# Altium schematic sheet Sheet1.SchDoc(Sheet1)
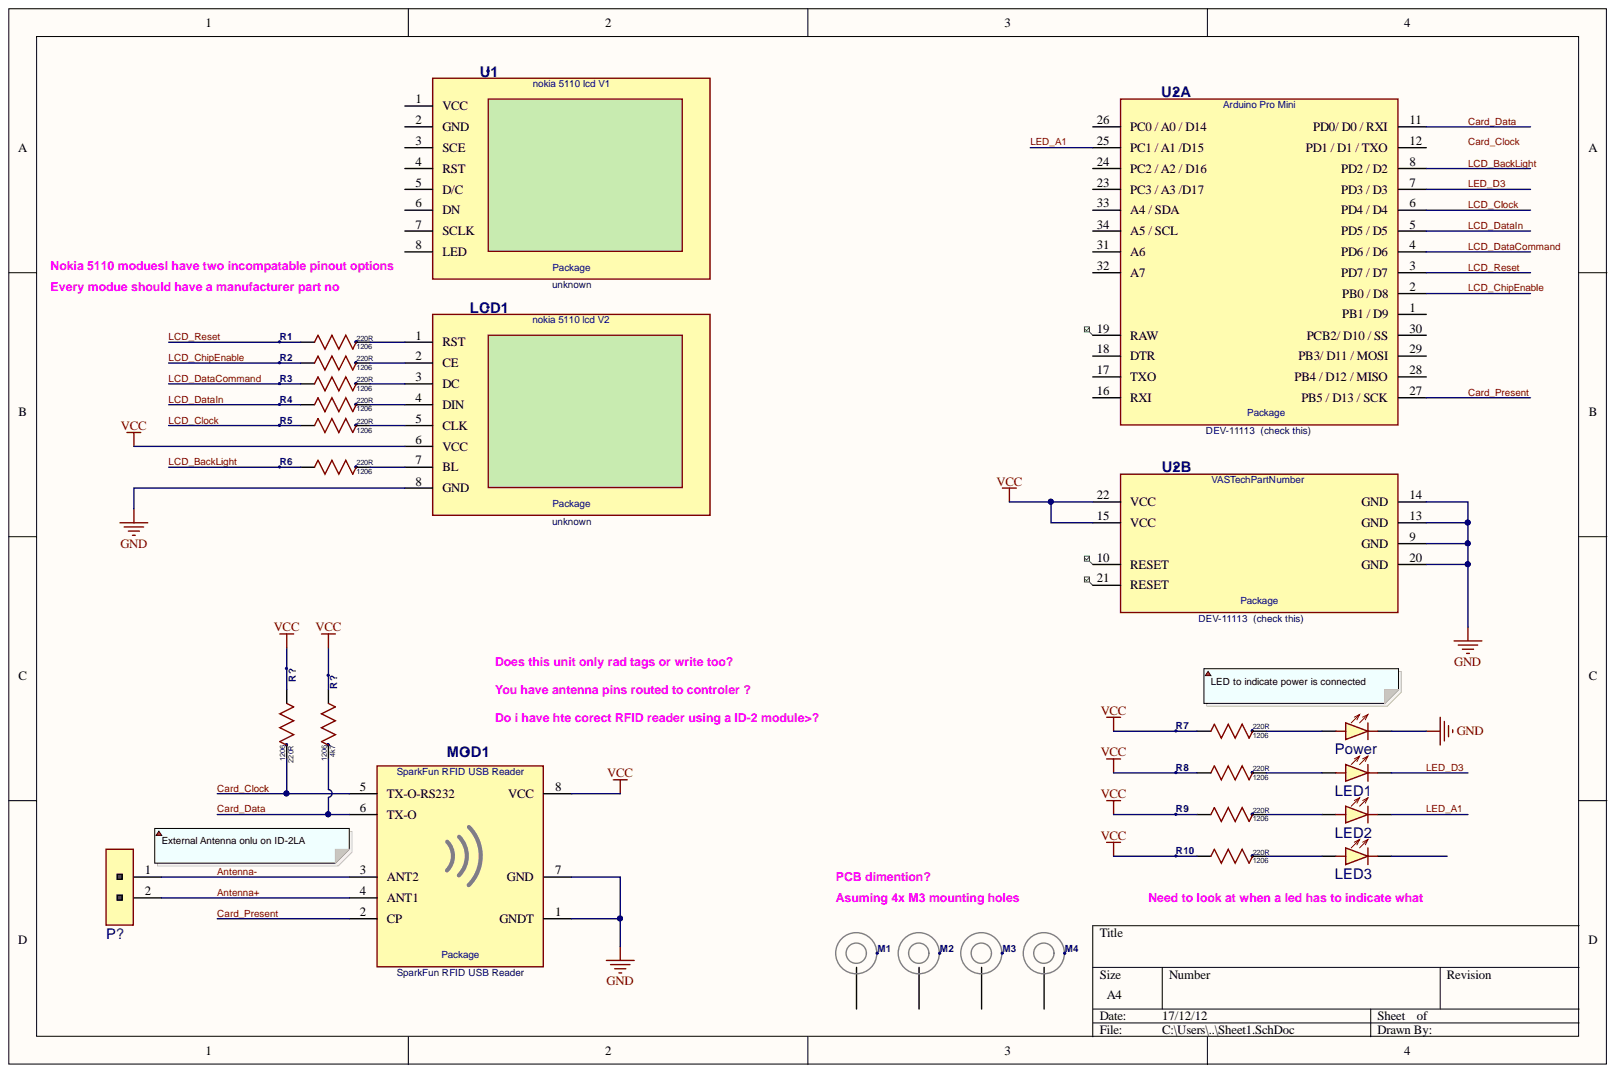
[diagram p0: Sheet1.SchDoc]

## Parts
LCD1  nokia 5110 lcd V2  SymbolAttribute: nokia 5110 lcd  ManufacturerCode: unknown  FootprintIndName: Package  [Nokia 5110 GLCD 84x48]
LED1  Red LED  [LED 1206 H0-55]  (value not drawn)
LED2  Red LED  [LED 1206 H0-55]  (value not drawn)
LED3  Red LED  [LED 1206 H0-55]  (value not drawn)
M1  1206  [M3 Mounting Hole]  (value not drawn)
M2  1206  [M3 Mounting Hole]  (value not drawn)
M3  1206  [M3 Mounting Hole]  (value not drawn)
M4  1206  [M3 Mounting Hole]  (value not drawn)
MOD1  SparkFun RFID USB Reader  FootprintIndName: Package  [SparkFun RFID USB Reader]
P?  VASTechPartNumber  [Con Molex 90120 2-Way]
Power  Red LED  [LED 1206 H0-55]  (value not drawn)
R1  1206  Attributes: 220R  [Res 1206 H0-55]
R2  1206  Attributes: 220R  [Res 1206 H0-55]
R3  1206  Attributes: 220R  [Res 1206 H0-55]
R4  1206  Attributes: 220R  [Res 1206 H0-55]
R5  1206  Attributes: 220R  [Res 1206 H0-55]
R6  1206  Attributes: 220R  [Res 1206 H0-55]
R7  1206  Attributes: 220R  [Res 1206 H0-55]
R8  1206  Attributes: 220R  [Res 1206 H0-55]
R9  1206  Attributes: 220R  [Res 1206 H0-55]
R10  1206  Attributes: 220R  [Res 1206 H0-55]
R?  1206  Attributes: 4k7  [Res 1206 H0-55]
R?  1206  [Res 1206 H0-55]
U1  nokia 5110 lcd V1  SymbolAttribute: nokia 5110 lcd  ManufacturerCode: unknown  FootprintIndName: Package  [Nokia 5110 GLCD 84x48]
U2  Arduino Pro Mini  ManufacturerCode: DEV-11113  (check this)  FootprintIndName: Package  [ARDUINO PRO MINI]  (parts A,B)

## Nets
GND: LCD1.8(GND) MOD1.1(GNDT) MOD1.7(GND) Power.2 U2.9(GND) U2.13(GND) U2.14(GND) U2.20(GND)
VCC: LCD1.6(VCC) MOD1.8(VCC) R7.1 R8.1 R9.1 R10.1 R?.1 U2.15(VCC) U2.22(VCC)
Antenna+: MOD1.4(ANT1) P?.2
Antenna-: MOD1.3(ANT2) P?.1
Card_Clock: MOD1.5(TX-O-RS232) R?.2
Card_Data: MOD1.6(TX-O) R?.2 U2.11(PD0/_D0_/_RXI)
Card_Present: MOD1.2(CP) U2.27(PB5_/_D13_/_SCK)
LCD_BackLight: R6.1 U2.8(PD2_/_D2)
LCD_ChipEnable: R2.1 U2.2(PB0_/_D8)
LCD_Clock: R5.1 U2.6(PD4_/_D4)
LCD_DataCommand: R3.1 U2.4(PD6_/_D6)
LCD_DataIn: R4.1 U2.5(PD5_/_D5)
LCD_Reset: R1.1 U2.3(PD7_/_D7)
LED_A1: LED2.2 U2.25(PC1_/_A1_/D15)
LED_D3: LED1.2 U2.7(PD3_/_D3)
NetLCD1_1: LCD1.1(RST) R1.2
NetLCD1_2: LCD1.2(CE) R2.2
NetLCD1_3: LCD1.3(DC) R3.2
NetLCD1_4: LCD1.4(DIN) R4.2
NetLCD1_5: LCD1.5(CLK) R5.2
NetLCD1_7: LCD1.7(BL) R6.2
NetLED1_1: LED1.1 R8.2
NetLED2_1: LED2.1 R9.2
NetLED3_1: LED3.1 R10.2
NetPower_1: Power.1 R7.2

Not connected: LED3 2; M1 1; M2 1; M3 1; M4 1; U1 1(VCC) 2(GND) 3(SCE) 4(RST) 5(D/C) 6(DN) 7(SCLK) 8(LED); U2 1(PB1_/_D9) 10(RESET) 12(PD1_/_D1_/_TXO) 16(RXI) 17(TXO) 18(DTR) 19(RAW) 21(RESET) 23(PC3_/_A3_/D17) 24(PC2_/_A2_/_D16) 26(PC0_/_A0_/_D14) 28(PB4_/_D12_/_MISO) 29(PB3/_D11_/_MOSI) 30(PCB2/_D10_/_SS) 31(A6) 32(A7) 33(A4_/_SDA) 34(A5_/_SCL)

## Library data of the parts
LED1-LED3 Power = (no symbol name): No Part Net Tie 2 Pin 0.4mm Track; ManufacturerPartNumber: KPT-3216ID; Manufacturer: KingBright; Package: 1206; Component Kind: Net Tie; Attributes: Red LED; Library Name: Copy of Undero_Symbols_v3.SchLib
M1-M4 = (no symbol name): Mounting Hole; Attributes: M3-7; Package: 1206; Component Kind: Standard; Library Name: Copy of Undero_Symbols_v3.SchLib
P? = (no symbol name): Header 2x1 2.54mm; ManufacturerPartNumber: 90120; Manufacturer: Molex; Component Kind: Standard; Attributes: 2x1 2.54mm; Library Name: Copy of Undero_Symbols_v3.SchLib
R1-R10 R? = (no symbol name): Resistor; Package: 1206; Component Kind: Standard; Library Name: Copy of Undero_Symbols_v3.SchLib
R? = 220R: Resistor; Database Table Name: public.altium_all; Package: 1206; Component Kind: Standard; Attributes: 220R; Library Name: VASTech_ERP.DbLib
U2 = Arduino Pro Mini: Manufacturer: SparkFun Electronics; SymbolAttribute: Arduino Pro Mini; Info: Arduino Pro Mini; Description: Arduino Pro Mini; Component Kind: Standard; Library Name: Undero_Symbols_v2.SchLib
LCD1 = nokia 5110 lcd V2: Manufacturer: unknown; Info: nokia 5110 lcd; Description: nokia 5110 lcd V2; Component Kind: Standard; Library Name: Undero_Symbols_v2.SchLib
MOD1 = SparkFun RFID USB Reader: SymbolAttribute: SparkFun RFID USB Reader; ManufacturerCode: SparkFun RFID USB Reader; Manufacturer: Sparkfun; Info: SparkFun RFID USB Reader; Description: SparkFun RFID USB Reader; Component Kind: Standard; Library Name: Undero_Symbols_v2.SchLib
U1 = nokia 5110 lcd V1: Manufacturer: unknown; Info: nokia 5110 lcd; Description: nokia 5110 lcd V1; Component Kind: Standard; Library Name: Undero_Symbols_v2.SchLib
